# Altium schematic sheet 419-005-01-02_PG 06 - CV SoC - HPS Banks.SchDoc("419-005-01-02_PG 06 - CV SoC - HPS Banks")
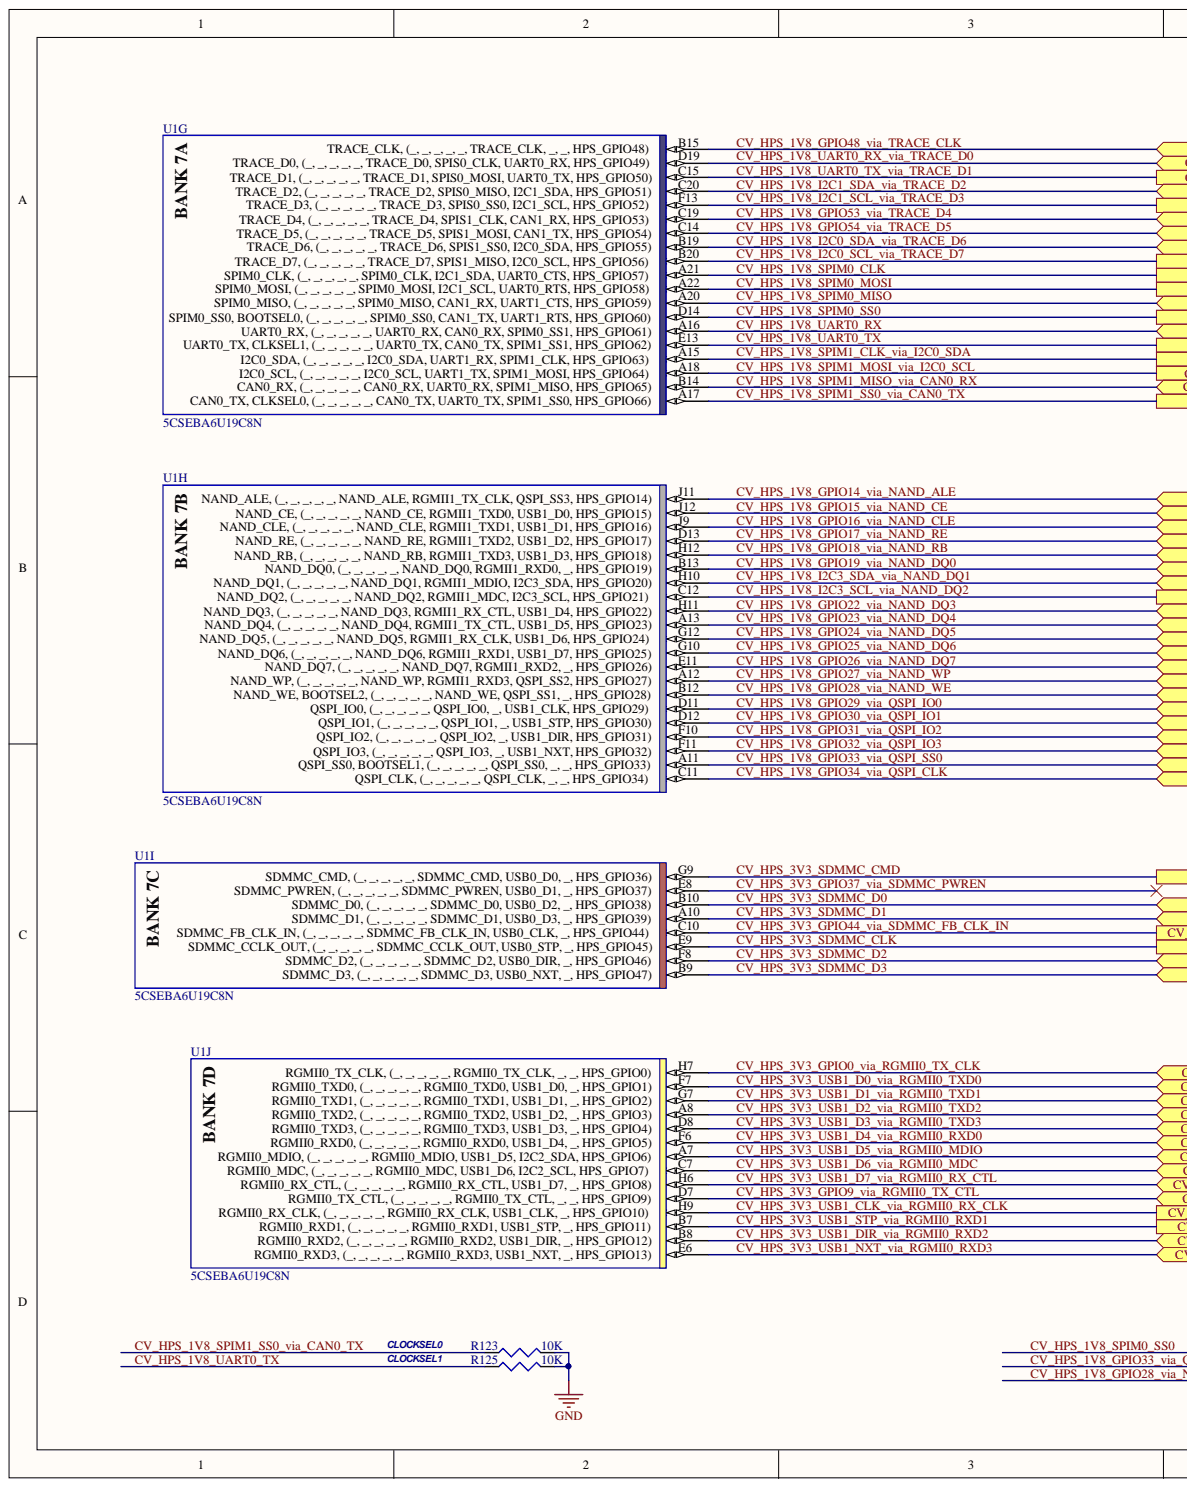
[diagram p0: 419-005-01-02_PG 06 - CV SoC - HPS Banks.SchDoc, left]
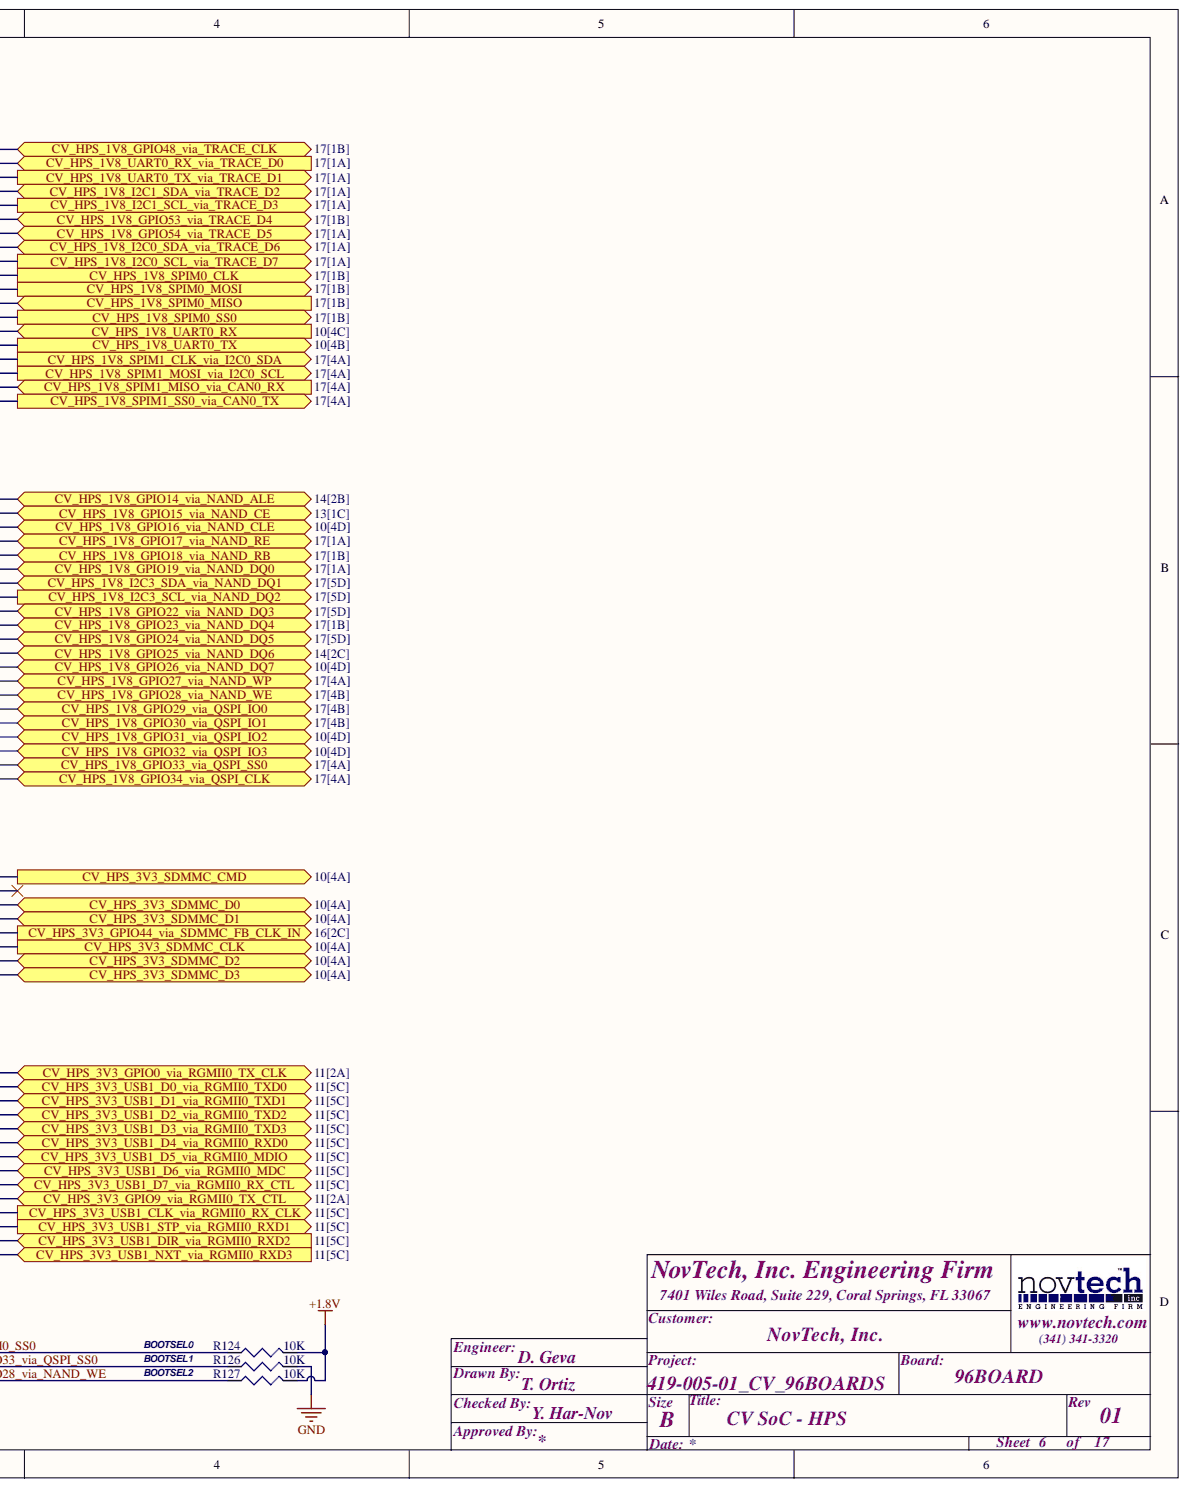
[diagram p1: 419-005-01-02_PG 06 - CV SoC - HPS Banks.SchDoc, right]

## Parts
R123  10K  [0201_R]
R124  10K  [0201_R]
R125  10K  [0201_R]
R126  10K  [0201_R]
R127  10K  [0201_R]
U1  5CSEBA6U19C8N  [BGA484C80P22X22_1900X1900X190]  (parts G,H,I,J)

## Ports
CV_HPS_1V8_GPIO14_VIA_NAND_ALE, CV_HPS_1V8_GPIO15_VIA_NAND_CE, CV_HPS_1V8_GPIO16_VIA_NAND_CLE, CV_HPS_1V8_GPIO17_VIA_NAND_RE, CV_HPS_1V8_GPIO18_VIA_NAND_RB, CV_HPS_1V8_GPIO19_VIA_NAND_DQ0, CV_HPS_1V8_GPIO22_VIA_NAND_DQ3, CV_HPS_1V8_GPIO23_VIA_NAND_DQ4, CV_HPS_1V8_GPIO24_VIA_NAND_DQ5, CV_HPS_1V8_GPIO25_VIA_NAND_DQ6, CV_HPS_1V8_GPIO26_VIA_NAND_DQ7, CV_HPS_1V8_GPIO27_VIA_NAND_WP, CV_HPS_1V8_GPIO28_VIA_NAND_WE, CV_HPS_1V8_GPIO29_VIA_QSPI_IO0, CV_HPS_1V8_GPIO30_VIA_QSPI_IO1, CV_HPS_1V8_GPIO31_VIA_QSPI_IO2, CV_HPS_1V8_GPIO32_VIA_QSPI_IO3, CV_HPS_1V8_GPIO33_VIA_QSPI_SS0, CV_HPS_1V8_GPIO34_VIA_QSPI_CLK, CV_HPS_1V8_GPIO48_VIA_TRACE_CLK, CV_HPS_1V8_GPIO53_VIA_TRACE_D4, CV_HPS_1V8_GPIO54_VIA_TRACE_D5, CV_HPS_1V8_I2C0_SCL_VIA_TRACE_D7, CV_HPS_1V8_I2C0_SDA_VIA_TRACE_D6, CV_HPS_1V8_I2C1_SCL_VIA_TRACE_D3, CV_HPS_1V8_I2C1_SDA_VIA_TRACE_D2, CV_HPS_1V8_I2C3_SCL_VIA_NAND_DQ2, CV_HPS_1V8_I2C3_SDA_VIA_NAND_DQ1, CV_HPS_1V8_SPIM0_CLK, CV_HPS_1V8_SPIM0_MISO, CV_HPS_1V8_SPIM0_MOSI, CV_HPS_1V8_SPIM0_SS0, CV_HPS_1V8_SPIM1_CLK_VIA_I2C0_SDA, CV_HPS_1V8_SPIM1_MISO_VIA_CAN0_RX, CV_HPS_1V8_SPIM1_MOSI_VIA_I2C0_SCL, CV_HPS_1V8_SPIM1_SS0_VIA_CAN0_TX, CV_HPS_1V8_UART0_RX, CV_HPS_1V8_UART0_RX_VIA_TRACE_D0, CV_HPS_1V8_UART0_TX, CV_HPS_1V8_UART0_TX_VIA_TRACE_D1, CV_HPS_3V3_GPIO0_VIA_RGMII0_TX_CLK, CV_HPS_3V3_GPIO9_VIA_RGMII0_TX_CTL, CV_HPS_3V3_GPIO44_VIA_SDMMC_FB_CLK_IN, CV_HPS_3V3_SDMMC_CLK, CV_HPS_3V3_SDMMC_CMD, CV_HPS_3V3_SDMMC_D0, CV_HPS_3V3_SDMMC_D1, CV_HPS_3V3_SDMMC_D2, CV_HPS_3V3_SDMMC_D3, CV_HPS_3V3_USB1_CLK_VIA_RGMII0_RX_CLK, CV_HPS_3V3_USB1_D0_VIA_RGMII0_TXD0, CV_HPS_3V3_USB1_D1_VIA_RGMII0_TXD1, CV_HPS_3V3_USB1_D2_VIA_RGMII0_TXD2, CV_HPS_3V3_USB1_D3_VIA_RGMII0_TXD3, CV_HPS_3V3_USB1_D4_VIA_RGMII0_RXD0, CV_HPS_3V3_USB1_D5_VIA_RGMII0_MDIO, CV_HPS_3V3_USB1_D6_VIA_RGMII0_MDC, CV_HPS_3V3_USB1_D7_VIA_RGMII0_RX_CTL, CV_HPS_3V3_USB1_DIR_VIA_RGMII0_RXD2, CV_HPS_3V3_USB1_NXT_VIA_RGMII0_RXD3, CV_HPS_3V3_USB1_STP_VIA_RGMII0_RXD1

## Nets
+1.8V: R124.2 R127.2
GND: R123.2 R125.2 R126.2
CV_HPS_1V8_GPIO14_VIA_NAND_ALE: U1.J11(NAND_ALE,_(_,__,__,__,__,_NAND_ALE,_RGMII1_TX_CLK,_QSPI_SS3,_HPS_GPIO14)) ; port CV_HPS_1V8_GPIO14_VIA_NAND_ALE
CV_HPS_1V8_GPIO15_VIA_NAND_CE: U1.J12(NAND_CE,_(_,__,__,__,__,_NAND_CE,_RGMII1_TXD0,_USB1_D0,_HPS_GPIO15)) ; port CV_HPS_1V8_GPIO15_VIA_NAND_CE
CV_HPS_1V8_GPIO16_VIA_NAND_CLE: U1.J9(NAND_CLE,_(_,__,__,__,__,_NAND_CLE,_RGMII1_TXD1,_USB1_D1,_HPS_GPIO16)) ; port CV_HPS_1V8_GPIO16_VIA_NAND_CLE
CV_HPS_1V8_GPIO17_VIA_NAND_RE: U1.D13(NAND_RE,_(_,__,__,__,__,_NAND_RE,_RGMII1_TXD2,_USB1_D2,_HPS_GPIO17)) ; port CV_HPS_1V8_GPIO17_VIA_NAND_RE
CV_HPS_1V8_GPIO18_VIA_NAND_RB: U1.H12(NAND_RB,_(_,__,__,__,__,_NAND_RB,_RGMII1_TXD3,_USB1_D3,_HPS_GPIO18)) ; port CV_HPS_1V8_GPIO18_VIA_NAND_RB
CV_HPS_1V8_GPIO19_VIA_NAND_DQ0: U1.B13(NAND_DQ0,_(_,__,__,__,__,_NAND_DQ0,_RGMII1_RXD0,__,_HPS_GPIO19)) ; port CV_HPS_1V8_GPIO19_VIA_NAND_DQ0
CV_HPS_1V8_GPIO22_VIA_NAND_DQ3: U1.H11(NAND_DQ3,_(_,__,__,__,__,_NAND_DQ3,_RGMII1_RX_CTL,_USB1_D4,_HPS_GPIO22)) ; port CV_HPS_1V8_GPIO22_VIA_NAND_DQ3
CV_HPS_1V8_GPIO23_VIA_NAND_DQ4: U1.A13(NAND_DQ4,_(_,__,__,__,__,_NAND_DQ4,_RGMII1_TX_CTL,_USB1_D5,_HPS_GPIO23)) ; port CV_HPS_1V8_GPIO23_VIA_NAND_DQ4
CV_HPS_1V8_GPIO24_VIA_NAND_DQ5: U1.G12(NAND_DQ5,_(_,__,__,__,__,_NAND_DQ5,_RGMII1_RX_CLK,_USB1_D6,_HPS_GPIO24)) ; port CV_HPS_1V8_GPIO24_VIA_NAND_DQ5
CV_HPS_1V8_GPIO25_VIA_NAND_DQ6: U1.G10(NAND_DQ6,_(_,__,__,__,__,_NAND_DQ6,_RGMII1_RXD1,_USB1_D7,_HPS_GPIO25)) ; port CV_HPS_1V8_GPIO25_VIA_NAND_DQ6
CV_HPS_1V8_GPIO26_VIA_NAND_DQ7: U1.E11(NAND_DQ7,_(_,__,__,__,__,_NAND_DQ7,_RGMII1_RXD2,__,_HPS_GPIO26)) ; port CV_HPS_1V8_GPIO26_VIA_NAND_DQ7
CV_HPS_1V8_GPIO27_VIA_NAND_WP: U1.A12(NAND_WP,_(_,__,__,__,__,_NAND_WP,_RGMII1_RXD3,_QSPI_SS2,_HPS_GPIO27)) ; port CV_HPS_1V8_GPIO27_VIA_NAND_WP
CV_HPS_1V8_GPIO28_VIA_NAND_WE: R127.1 U1.B12(NAND_WE,_BOOTSEL2,_(_,__,__,__,__,_NAND_WE,_QSPI_SS1,__,_HPS_GPIO28)) ; port CV_HPS_1V8_GPIO28_VIA_NAND_WE
CV_HPS_1V8_GPIO29_VIA_QSPI_IO0: U1.D11(QSPI_IO0,_(_,__,__,__,__,_QSPI_IO0,__,_USB1_CLK,_HPS_GPIO29)) ; port CV_HPS_1V8_GPIO29_VIA_QSPI_IO0
CV_HPS_1V8_GPIO30_VIA_QSPI_IO1: U1.D12(QSPI_IO1,_(_,__,__,__,__,_QSPI_IO1,__,_USB1_STP,_HPS_GPIO30)) ; port CV_HPS_1V8_GPIO30_VIA_QSPI_IO1
CV_HPS_1V8_GPIO31_VIA_QSPI_IO2: U1.F10(QSPI_IO2,_(_,__,__,__,__,_QSPI_IO2,__,_USB1_DIR,_HPS_GPIO31)) ; port CV_HPS_1V8_GPIO31_VIA_QSPI_IO2
CV_HPS_1V8_GPIO32_VIA_QSPI_IO3: U1.F11(QSPI_IO3,_(_,__,__,__,__,_QSPI_IO3,__,_USB1_NXT,_HPS_GPIO32)) ; port CV_HPS_1V8_GPIO32_VIA_QSPI_IO3
CV_HPS_1V8_GPIO33_VIA_QSPI_SS0: R126.1 U1.A11(QSPI_SS0,_BOOTSEL1,_(_,__,__,__,__,_QSPI_SS0,__,__,_HPS_GPIO33)) ; port CV_HPS_1V8_GPIO33_VIA_QSPI_SS0
CV_HPS_1V8_GPIO34_VIA_QSPI_CLK: U1.C11(QSPI_CLK,_(_,__,__,__,__,_QSPI_CLK,__,__,_HPS_GPIO34)) ; port CV_HPS_1V8_GPIO34_VIA_QSPI_CLK
CV_HPS_1V8_GPIO48_VIA_TRACE_CLK: U1.B15(TRACE_CLK,_(_,__,__,__,__,_TRACE_CLK,__,__,_HPS_GPIO48)) ; port CV_HPS_1V8_GPIO48_VIA_TRACE_CLK
CV_HPS_1V8_GPIO53_VIA_TRACE_D4: U1.C19(TRACE_D4,_(_,__,__,__,__,_TRACE_D4,_SPIS1_CLK,_CAN1_RX,_HPS_GPIO53)) ; port CV_HPS_1V8_GPIO53_VIA_TRACE_D4
CV_HPS_1V8_GPIO54_VIA_TRACE_D5: U1.C14(TRACE_D5,_(_,__,__,__,__,_TRACE_D5,_SPIS1_MOSI,_CAN1_TX,_HPS_GPIO54)) ; port CV_HPS_1V8_GPIO54_VIA_TRACE_D5
CV_HPS_1V8_I2C0_SCL_VIA_TRACE_D7: U1.B20(TRACE_D7,_(_,__,__,__,__,_TRACE_D7,_SPIS1_MISO,_I2C0_SCL,_HPS_GPIO56)) ; port CV_HPS_1V8_I2C0_SCL_VIA_TRACE_D7
CV_HPS_1V8_I2C0_SDA_VIA_TRACE_D6: U1.B19(TRACE_D6,_(_,__,__,__,__,_TRACE_D6,_SPIS1_SS0,_I2C0_SDA,_HPS_GPIO55)) ; port CV_HPS_1V8_I2C0_SDA_VIA_TRACE_D6
CV_HPS_1V8_I2C1_SCL_VIA_TRACE_D3: U1.F13(TRACE_D3,_(_,__,__,__,__,_TRACE_D3,_SPIS0_SS0,_I2C1_SCL,_HPS_GPIO52)) ; port CV_HPS_1V8_I2C1_SCL_VIA_TRACE_D3
CV_HPS_1V8_I2C1_SDA_VIA_TRACE_D2: U1.C20(TRACE_D2,_(_,__,__,__,__,_TRACE_D2,_SPIS0_MISO,_I2C1_SDA,_HPS_GPIO51)) ; port CV_HPS_1V8_I2C1_SDA_VIA_TRACE_D2
CV_HPS_1V8_I2C3_SCL_VIA_NAND_DQ2: U1.C12(NAND_DQ2,_(_,__,__,__,__,_NAND_DQ2,_RGMII1_MDC,_I2C3_SCL,_HPS_GPIO21)) ; port CV_HPS_1V8_I2C3_SCL_VIA_NAND_DQ2
CV_HPS_1V8_I2C3_SDA_VIA_NAND_DQ1: U1.H10(NAND_DQ1,_(_,__,__,__,__,_NAND_DQ1,_RGMII1_MDIO,_I2C3_SDA,_HPS_GPIO20)) ; port CV_HPS_1V8_I2C3_SDA_VIA_NAND_DQ1
CV_HPS_1V8_SPIM0_CLK: U1.A21(SPIM0_CLK,_(_,__,__,__,__,_SPIM0_CLK,_I2C1_SDA,_UART0_CTS,_HPS_GPIO57)) ; port CV_HPS_1V8_SPIM0_CLK
CV_HPS_1V8_SPIM0_MISO: U1.A20(SPIM0_MISO,_(_,__,__,__,__,_SPIM0_MISO,_CAN1_RX,_UART1_CTS,_HPS_GPIO59)) ; port CV_HPS_1V8_SPIM0_MISO
CV_HPS_1V8_SPIM0_MOSI: U1.A22(SPIM0_MOSI,_(_,__,__,__,__,_SPIM0_MOSI,_I2C1_SCL,_UART0_RTS,_HPS_GPIO58)) ; port CV_HPS_1V8_SPIM0_MOSI
CV_HPS_1V8_SPIM0_SS0: R124.1 U1.D14(SPIM0_SS0,_BOOTSEL0,_(_,__,__,__,__,_SPIM0_SS0,_CAN1_TX,_UART1_RTS,_HPS_GPIO60)) ; port CV_HPS_1V8_SPIM0_SS0
CV_HPS_1V8_SPIM1_CLK_VIA_I2C0_SDA: U1.A15(I2C0_SDA,_(_,__,__,__,__,_I2C0_SDA,_UART1_RX,_SPIM1_CLK,_HPS_GPIO63)) ; port CV_HPS_1V8_SPIM1_CLK_VIA_I2C0_SDA
CV_HPS_1V8_SPIM1_MISO_VIA_CAN0_RX: U1.B14(CAN0_RX,_(_,__,__,__,__,_CAN0_RX,_UART0_RX,_SPIM1_MISO,_HPS_GPIO65)) ; port CV_HPS_1V8_SPIM1_MISO_VIA_CAN0_RX
CV_HPS_1V8_SPIM1_MOSI_VIA_I2C0_SCL: U1.A18(I2C0_SCL,_(_,__,__,__,__,_I2C0_SCL,_UART1_TX,_SPIM1_MOSI,_HPS_GPIO64)) ; port CV_HPS_1V8_SPIM1_MOSI_VIA_I2C0_SCL
CV_HPS_1V8_SPIM1_SS0_VIA_CAN0_TX: R123.1 U1.A17(CAN0_TX,_CLKSEL0,_(_,__,__,__,__,_CAN0_TX,_UART0_TX,_SPIM1_SS0,_HPS_GPIO66)) ; port CV_HPS_1V8_SPIM1_SS0_VIA_CAN0_TX
CV_HPS_1V8_UART0_RX: U1.A16(UART0_RX,_(_,__,__,__,__,_UART0_RX,_CAN0_RX,_SPIM0_SS1,_HPS_GPIO61)) ; port CV_HPS_1V8_UART0_RX
CV_HPS_1V8_UART0_RX_VIA_TRACE_D0: U1.D19(TRACE_D0,_(_,__,__,__,__,_TRACE_D0,_SPIS0_CLK,_UART0_RX,_HPS_GPIO49)) ; port CV_HPS_1V8_UART0_RX_VIA_TRACE_D0
CV_HPS_1V8_UART0_TX: R125.1 U1.E13(UART0_TX,_CLKSEL1,_(_,__,__,__,__,_UART0_TX,_CAN0_TX,_SPIM1_SS1,_HPS_GPIO62)) ; port CV_HPS_1V8_UART0_TX
CV_HPS_1V8_UART0_TX_VIA_TRACE_D1: U1.C15(TRACE_D1,_(_,__,__,__,__,_TRACE_D1,_SPIS0_MOSI,_UART0_TX,_HPS_GPIO50)) ; port CV_HPS_1V8_UART0_TX_VIA_TRACE_D1
CV_HPS_3V3_GPIO0_VIA_RGMII0_TX_CLK: U1.H7(RGMII0_TX_CLK,_(_,__,__,__,__,_RGMII0_TX_CLK,__,__,_HPS_GPIO0)) ; port CV_HPS_3V3_GPIO0_VIA_RGMII0_TX_CLK
CV_HPS_3V3_GPIO9_VIA_RGMII0_TX_CTL: U1.D7(RGMII0_TX_CTL,_(_,__,__,__,__,_RGMII0_TX_CTL,__,__,_HPS_GPIO9)) ; port CV_HPS_3V3_GPIO9_VIA_RGMII0_TX_CTL
CV_HPS_3V3_GPIO37_VIA_SDMMC_PWREN: U1.E8(SDMMC_PWREN,_(_,__,__,__,__,_SDMMC_PWREN,_USB0_D1,__,_HPS_GPIO37))
CV_HPS_3V3_GPIO44_VIA_SDMMC_FB_CLK_IN: U1.C10(SDMMC_FB_CLK_IN,_(_,__,__,__,__,_SDMMC_FB_CLK_IN,_USB0_CLK,__,_HPS_GPIO44)) ; port CV_HPS_3V3_GPIO44_VIA_SDMMC_FB_CLK_IN
CV_HPS_3V3_SDMMC_CLK: U1.E9(SDMMC_CCLK_OUT,_(_,__,__,__,__,_SDMMC_CCLK_OUT,_USB0_STP,__,_HPS_GPIO45)) ; port CV_HPS_3V3_SDMMC_CLK
CV_HPS_3V3_SDMMC_CMD: U1.G9(SDMMC_CMD,_(_,__,__,__,__,_SDMMC_CMD,_USB0_D0,__,_HPS_GPIO36)) ; port CV_HPS_3V3_SDMMC_CMD
CV_HPS_3V3_SDMMC_D0: U1.B10(SDMMC_D0,_(_,__,__,__,__,_SDMMC_D0,_USB0_D2,__,_HPS_GPIO38)) ; port CV_HPS_3V3_SDMMC_D0
CV_HPS_3V3_SDMMC_D1: U1.A10(SDMMC_D1,_(_,__,__,__,__,_SDMMC_D1,_USB0_D3,__,_HPS_GPIO39)) ; port CV_HPS_3V3_SDMMC_D1
CV_HPS_3V3_SDMMC_D2: U1.F8(SDMMC_D2,_(_,__,__,__,__,_SDMMC_D2,_USB0_DIR,__,_HPS_GPIO46)) ; port CV_HPS_3V3_SDMMC_D2
CV_HPS_3V3_SDMMC_D3: U1.B9(SDMMC_D3,_(_,__,__,__,__,_SDMMC_D3,_USB0_NXT,__,_HPS_GPIO47)) ; port CV_HPS_3V3_SDMMC_D3
CV_HPS_3V3_USB1_CLK_VIA_RGMII0_RX_CLK: U1.H9(RGMII0_RX_CLK,_(_,__,__,__,__,_RGMII0_RX_CLK,_USB1_CLK,__,_HPS_GPIO10)) ; port CV_HPS_3V3_USB1_CLK_VIA_RGMII0_RX_CLK
CV_HPS_3V3_USB1_D0_VIA_RGMII0_TXD0: U1.F7(RGMII0_TXD0,_(_,__,__,__,__,_RGMII0_TXD0,_USB1_D0,__,_HPS_GPIO1)) ; port CV_HPS_3V3_USB1_D0_VIA_RGMII0_TXD0
CV_HPS_3V3_USB1_D1_VIA_RGMII0_TXD1: U1.G7(RGMII0_TXD1,_(_,__,__,__,__,_RGMII0_TXD1,_USB1_D1,__,_HPS_GPIO2)) ; port CV_HPS_3V3_USB1_D1_VIA_RGMII0_TXD1
CV_HPS_3V3_USB1_D2_VIA_RGMII0_TXD2: U1.A8(RGMII0_TXD2,_(_,__,__,__,__,_RGMII0_TXD2,_USB1_D2,__,_HPS_GPIO3)) ; port CV_HPS_3V3_USB1_D2_VIA_RGMII0_TXD2
CV_HPS_3V3_USB1_D3_VIA_RGMII0_TXD3: U1.D8(RGMII0_TXD3,_(_,__,__,__,__,_RGMII0_TXD3,_USB1_D3,__,_HPS_GPIO4)) ; port CV_HPS_3V3_USB1_D3_VIA_RGMII0_TXD3
CV_HPS_3V3_USB1_D4_VIA_RGMII0_RXD0: U1.F6(RGMII0_RXD0,_(_,__,__,__,__,_RGMII0_RXD0,_USB1_D4,__,_HPS_GPIO5)) ; port CV_HPS_3V3_USB1_D4_VIA_RGMII0_RXD0
CV_HPS_3V3_USB1_D5_VIA_RGMII0_MDIO: U1.A7(RGMII0_MDIO,_(_,__,__,__,__,_RGMII0_MDIO,_USB1_D5,_I2C2_SDA,_HPS_GPIO6)) ; port CV_HPS_3V3_USB1_D5_VIA_RGMII0_MDIO
CV_HPS_3V3_USB1_D6_VIA_RGMII0_MDC: U1.C7(RGMII0_MDC,_(_,__,__,__,__,_RGMII0_MDC,_USB1_D6,_I2C2_SCL,_HPS_GPIO7)) ; port CV_HPS_3V3_USB1_D6_VIA_RGMII0_MDC
CV_HPS_3V3_USB1_D7_VIA_RGMII0_RX_CTL: U1.H6(RGMII0_RX_CTL,_(_,__,__,__,__,_RGMII0_RX_CTL,_USB1_D7,__,_HPS_GPIO8)) ; port CV_HPS_3V3_USB1_D7_VIA_RGMII0_RX_CTL
CV_HPS_3V3_USB1_DIR_VIA_RGMII0_RXD2: U1.B8(RGMII0_RXD2,_(_,__,__,__,__,_RGMII0_RXD2,_USB1_DIR,__,_HPS_GPIO12)) ; port CV_HPS_3V3_USB1_DIR_VIA_RGMII0_RXD2
CV_HPS_3V3_USB1_NXT_VIA_RGMII0_RXD3: U1.E6(RGMII0_RXD3,_(_,__,__,__,__,_RGMII0_RXD3,_USB1_NXT,__,_HPS_GPIO13)) ; port CV_HPS_3V3_USB1_NXT_VIA_RGMII0_RXD3
CV_HPS_3V3_USB1_STP_VIA_RGMII0_RXD1: U1.B7(RGMII0_RXD1,_(_,__,__,__,__,_RGMII0_RXD1,_USB1_STP,__,_HPS_GPIO11)) ; port CV_HPS_3V3_USB1_STP_VIA_RGMII0_RXD1

## Library data of the parts
R123-R127 = RESISOTR 0201: Resistor 0201 footprint; PART: ERJ-1GEF1002C; MFG: Panasonic; Component Kind: Standard; Digikey: P10.0KABCT-ND; Library Name: PASSIVES.SchLib; RoHS: Yes; TYPE: SMT
U1 = 5CSEBA6U19C8N: Cyclone V SE Family FPGA, 1.07 to 1.13 V Supply, 66 I/O Pins, 6 PLLs, 484-Pin UBGA, Speed Grade 7, Commercial Grade, RoHS Compliant; Manufacturer: Altera; Logic Elements (LE) (K): 110; Speed Grade: 8; Code_JEDEC: MO-275; Component Kind: Standard; ComponentLink1Description: Manufacturer URL; ComponentLink1URL: http://www.altera.com; ComponentLink2Description: Datasheet; ComponentLink2URL: http://www.altera.com/literature/hb/cyclone-v/cv_51002.pdf; ComponentLink3Description: Package Specification; ComponentLink3URL: http://www.altera.com/devicepackaging/04R00436-01.pdf; ComponentLink4Description: Device Handbook URL; ComponentLink4URL: http://www.altera.com/literature/hb/cyclone-v/cyclone5_handbook.pdf; ComponentLink5Description: Device Pin-Out URL; ComponentLink5URL: http://www.altera.com/literature/dp/cyclone-v/5CSEBA6.xls; ComponentLink6Description: Product URL; ComponentLink6URL: http://www.buyaltera.com/scripts/partsearch.dll?Detail&name=5CSEBA6U19C8N-ND; DatasheetVersion: Rev. 3.4; Device Group: 5CSEBA6; Library Name: IC_SchLib.SCHLIB; Mounting Technology: Surface Mount; NexusDeviceID: 5CSEBA6U19C8N; PackageDescription: 484-Pin Ultra FineLine Ball-Grid Array (UBGA), Body 19 x 19 mm, Pitch 0.8 mm; PackageReference: UBGA484_A-190; PackageVersion: Rev. 1.0, 12/2011; PartNumber: 5CSEBA6U19C8N; RoHS: RoHS Compliant; Subcategory: Cyclone V SE; Supplier 1: Digi-Key; Supplier 2: Mouser; Supplier Part Number 1: 5CSEBA6U19C8N-ND; Supplier Part Number 2: 989-5CSEBA6U19C8N; Voltage Supply: 1.07 to 1.13 V
